# Altium schematic sheet Debug.SchDoc(Debug)
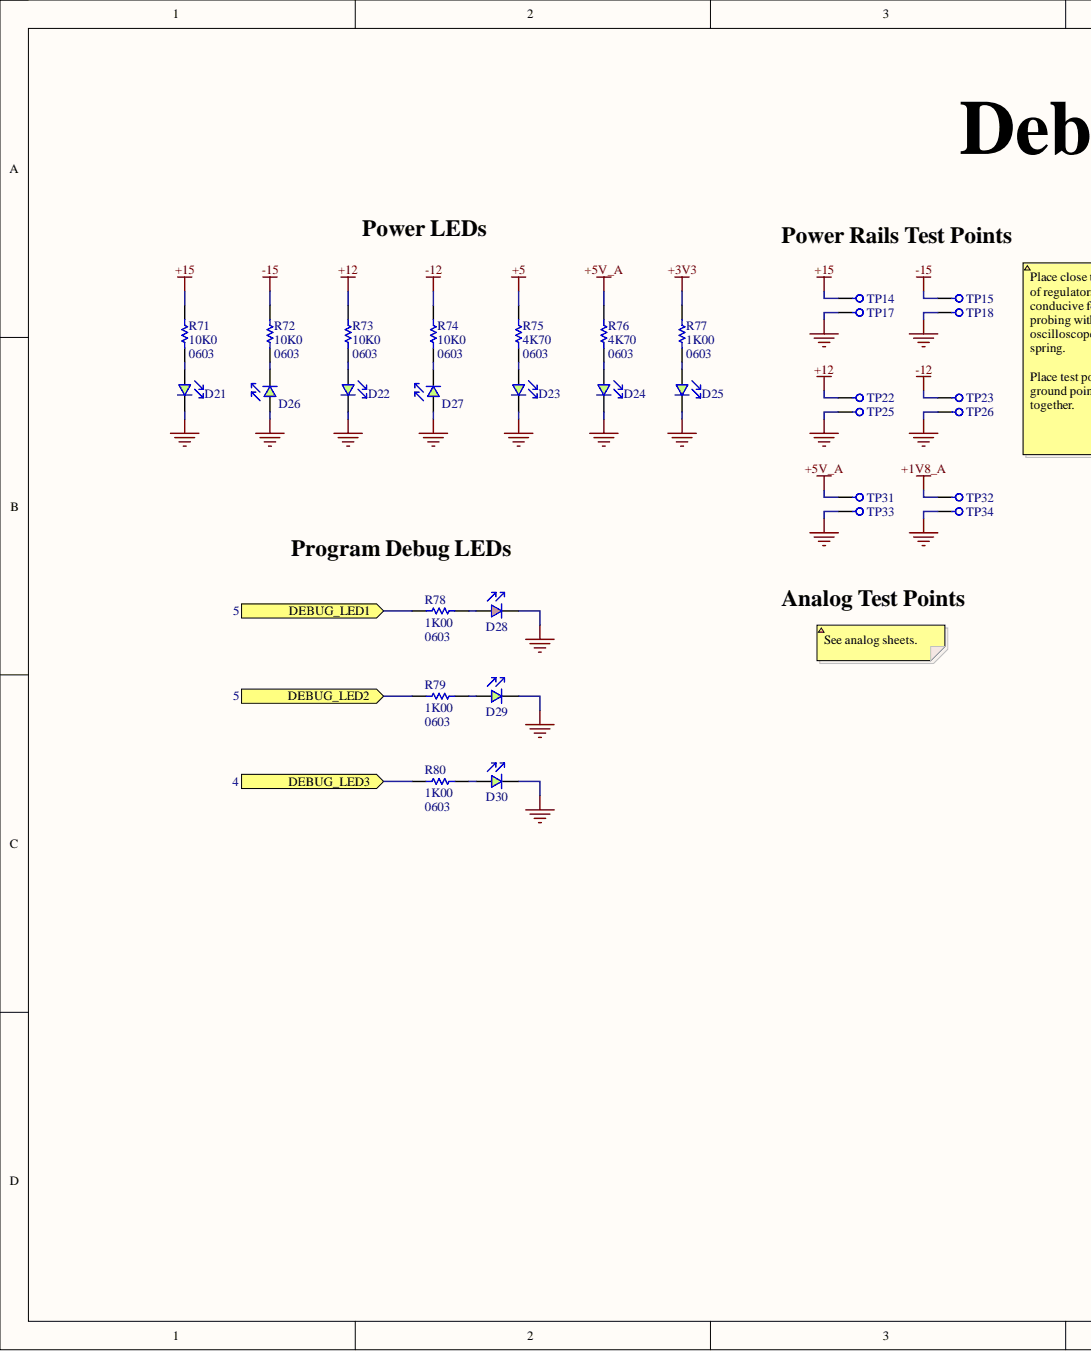
[diagram p0: Debug.SchDoc, left]
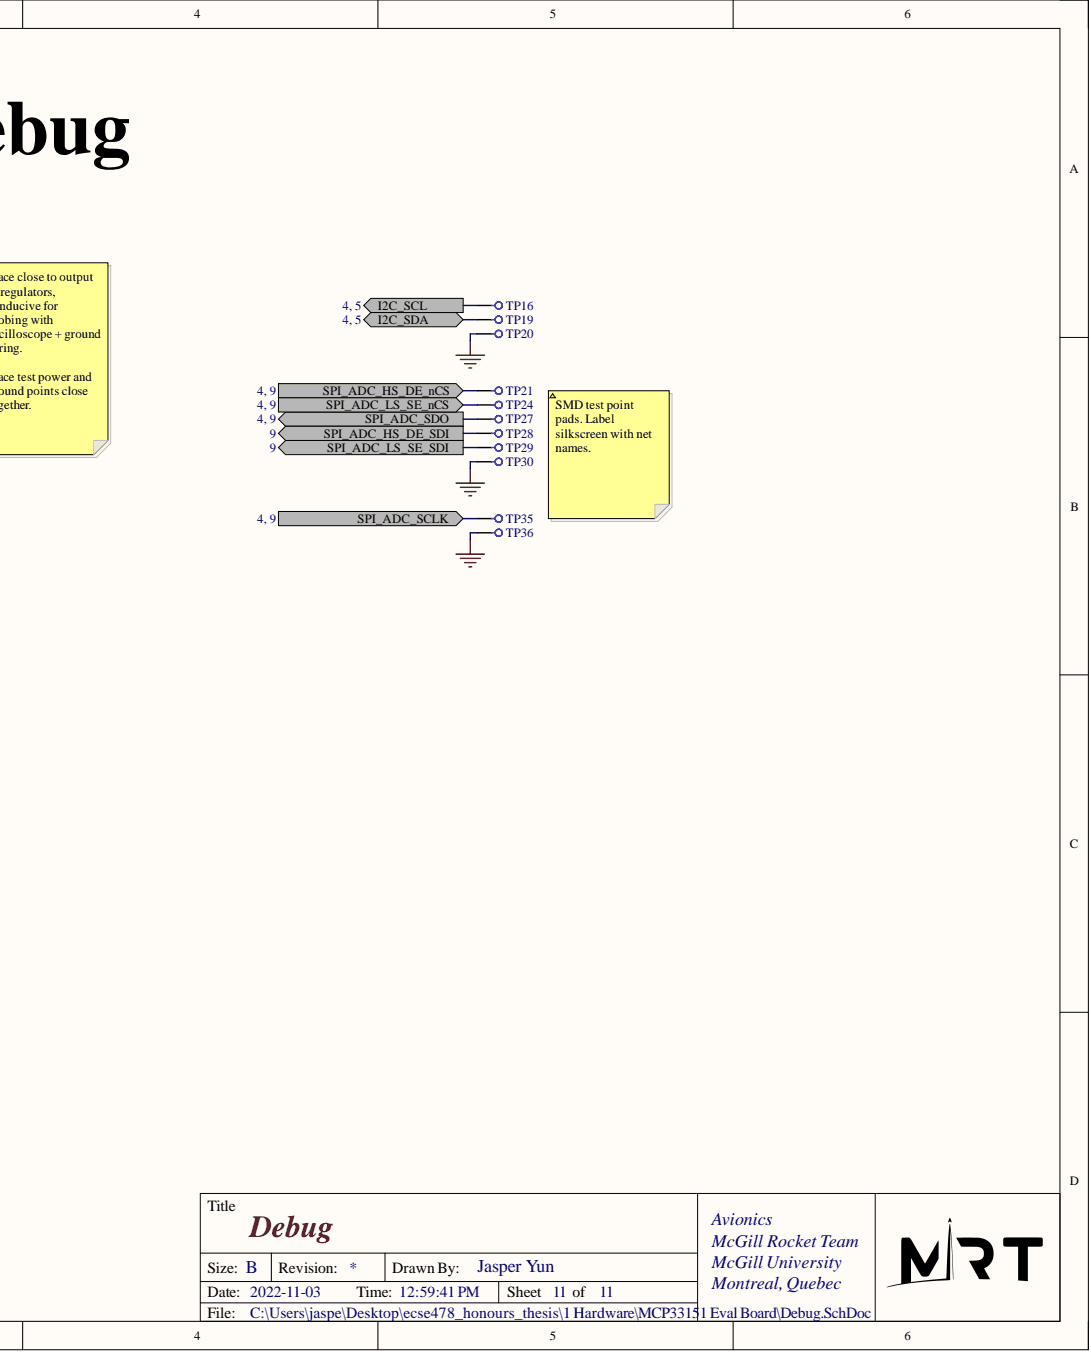
[diagram p1: Debug.SchDoc, right]

## Parts
D21  LED Green  [0603 LED]  (value not drawn)
D22  LED Green  [0603 LED]  (value not drawn)
D23  LED Green  [0603 LED]  (value not drawn)
D24  LED Green  [0603 LED]  (value not drawn)
D25  LED Green  [0603 LED]  (value not drawn)
D26  LED Green  [0603 LED]  (value not drawn)
D27  LED Green  [0603 LED]  (value not drawn)
D28  LED Red  [0603 LED]  (value not drawn)
D29  LED Green  [0603 LED]  (value not drawn)
D30  LED Green  [0603 LED]  (value not drawn)
R71  10K0  value 0603  [0603 RES]
R72  10K0  value 0603  [0603 RES]
R73  10K0  value 0603  [0603 RES]
R74  10K0  value 0603  [0603 RES]
R75  4K70  value 0603  [0603 RES]
R76  4K70  value 0603  [0603 RES]
R77  1K00  value 0603  [0603 RES]
R78  1K00  value 0603  [0603 RES]
R79  1K00  value 0603  [0603 RES]
R80  1K00  value 0603  [0603 RES]
TP14  Test Point  [Test Point Keystone 5015]
TP15  Test Point  [Test Point Keystone 5015]
TP16  Test Point  [TEST POINT SMD PAD]  (no BOM)
TP17  Test Point  [Test Point Keystone 5015]
TP18  Test Point  [Test Point Keystone 5015]
TP19  Test Point  [TEST POINT SMD PAD]  (no BOM)
TP20  Test Point  [TEST POINT SMD PAD]  (no BOM)
TP21  Test Point  [TEST POINT SMD PAD]  (no BOM)
TP22  Test Point  [Test Point Keystone 5015]
TP23  Test Point  [Test Point Keystone 5015]
TP24  Test Point  [TEST POINT SMD PAD]  (no BOM)
TP25  Test Point  [Test Point Keystone 5015]
TP26  Test Point  [Test Point Keystone 5015]
TP27  Test Point  [TEST POINT SMD PAD]  (no BOM)
TP28  Test Point  [TEST POINT SMD PAD]  (no BOM)
TP29  Test Point  [TEST POINT SMD PAD]  (no BOM)
TP30  Test Point  [TEST POINT SMD PAD]  (no BOM)
TP31  Test Point  [Test Point Keystone 5015]
TP32  Test Point  [Test Point Keystone 5015]
TP33  Test Point  [Test Point Keystone 5015]
TP34  Test Point  [Test Point Keystone 5015]
TP35  Test Point  [TEST POINT SMD PAD]  (no BOM)
TP36  Test Point  [TEST POINT SMD PAD]  (no BOM)

## Ports
DEBUG_LED1, DEBUG_LED2, DEBUG_LED3, I2C_SCL, I2C_SDA, SPI_ADC_HS_DE_nCS, SPI_ADC_HS_DE_SDI, SPI_ADC_LS_SE_nCS, SPI_ADC_LS_SE_SDI, SPI_ADC_SCLK, SPI_ADC_SDO

## Nets
+1V8_A: TP32.1
+3V3: R77.2
+5: R75.2
+5V_A: R76.2 TP31.1
+12: R73.2 TP22.1
+15: R71.2 TP14.1
-12: R74.2 TP23.1
-15: R72.2 TP15.1
GND: D21.2 D22.2 D23.2 D24.2 D25.2 D26.1 D27.1 D28.2 D29.2 D30.2 TP17.1 TP18.1 TP20.1 TP25.1 TP26.1 TP30.1 TP33.1 TP34.1 TP36.1
DEBUG_LED1: R78.2 ; port DEBUG_LED1
DEBUG_LED2: R79.2 ; port DEBUG_LED2
DEBUG_LED3: R80.2 ; port DEBUG_LED3
I2C_SCL: TP16.1 ; port I2C_SCL
I2C_SDA: TP19.1 ; port I2C_SDA
SPI_ADC_HS_DE_nCS: TP21.1 ; port SPI_ADC_HS_DE_nCS
SPI_ADC_HS_DE_SDI: TP28.1 ; port SPI_ADC_HS_DE_SDI
SPI_ADC_LS_SE_nCS: TP24.1 ; port SPI_ADC_LS_SE_nCS
SPI_ADC_LS_SE_SDI: TP29.1 ; port SPI_ADC_LS_SE_SDI
SPI_ADC_SCLK: TP35.1 ; port SPI_ADC_SCLK
SPI_ADC_SDO: TP27.1 ; port SPI_ADC_SDO
NetD21_1: D21.1 R71.1
NetD22_1: D22.1 R73.1
NetD23_1: D23.1 R75.1
NetD24_1: D24.1 R76.1
NetD25_1: D25.1 R77.1
NetD26_2: D26.2 R72.1
NetD27_2: D27.2 R74.1
NetD28_1: D28.1 R78.1
NetD29_1: D29.1 R79.1
NetD30_1: D30.1 R80.1

## Library data of the parts
D21-D27 D29 D30 = LED Green: Generic Green LED; Component Kind: Standard; Library Name: MRT SCH Library.SchLib
D28 = LED Red: Generic Red LED; Component Kind: Standard; Library Name: MRT SCH Library.SchLib
R71-R80 = Res1: Resistor; Component Kind: Standard; LatestRevisionDate: 17-Jul-2002; LatestRevisionNote: Re-released for DXP Platform.; Library Name: Miscellaneous Devices.IntLib; PackageDescription: Axial Device, Thru-Hole; 2 Leads; 0.3 in Pin Spacing; PackageReference: AXIAL-0.3; Published: 8-Jun-2000; Signal Integrity: Res; Simulation: RESISTOR; Publisher: Altium Limited
TP14-TP36 = Test Point: Keystone 5015 Test point; Library Name: MRT SCH Library.SchLib
  TP14 TP15 TP17 TP18 TP22 TP23 TP25 TP26 TP31-TP34: Component Kind: Standard
